# Altium schematic sheet SoftCore_SA_P4.SchDoc
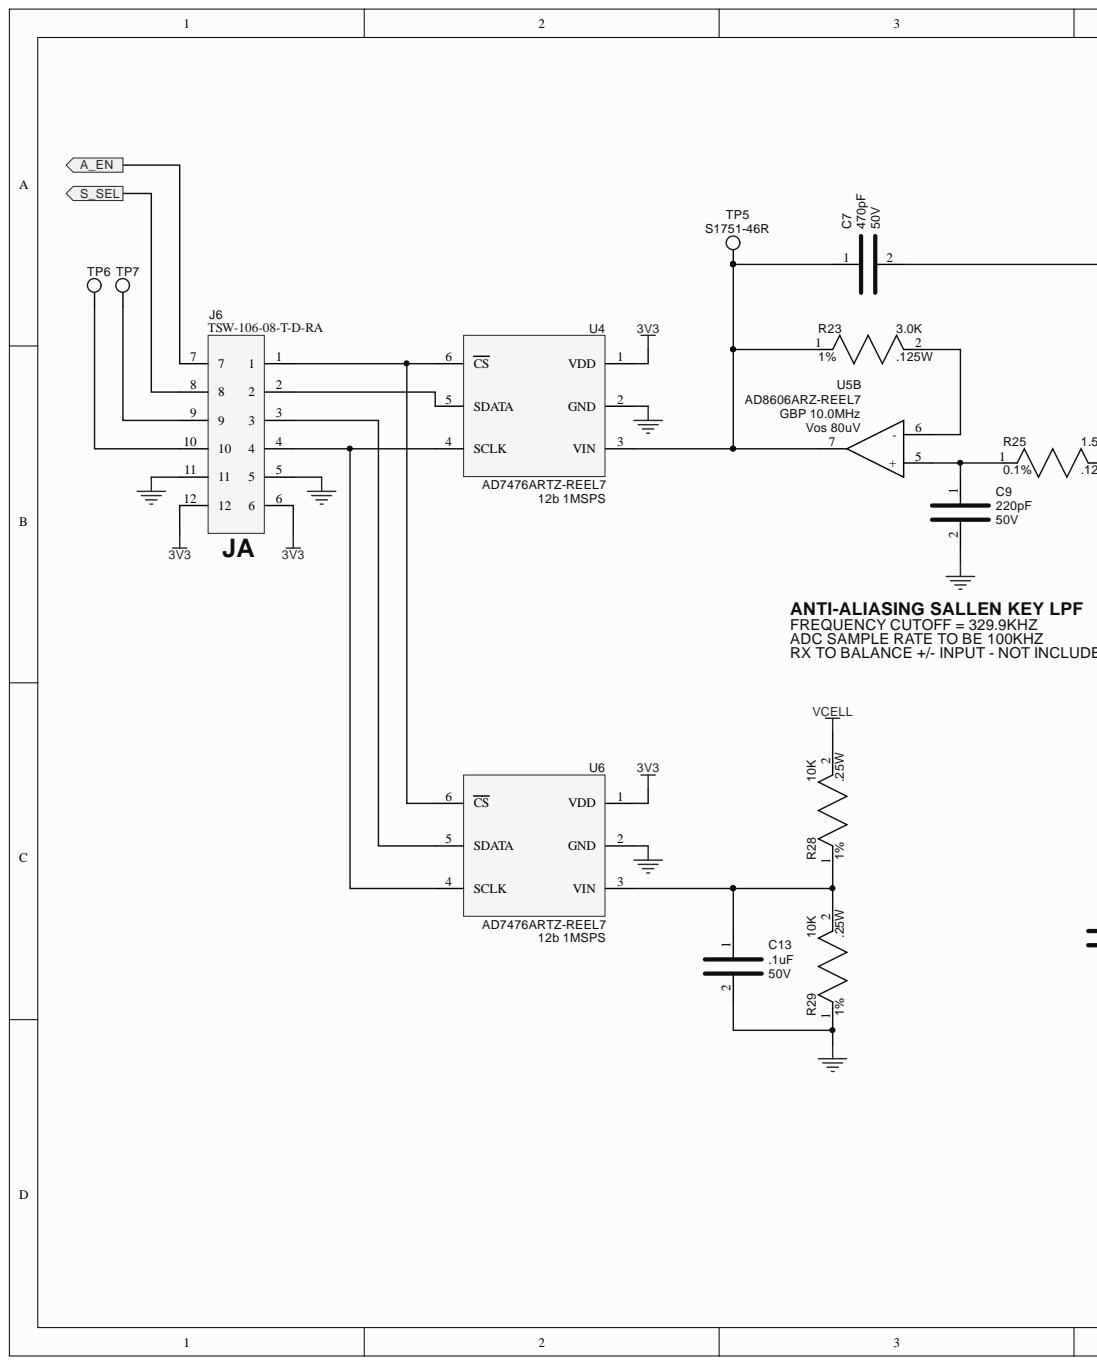
[diagram p0: SoftCore_SA_P4.SchDoc, left]
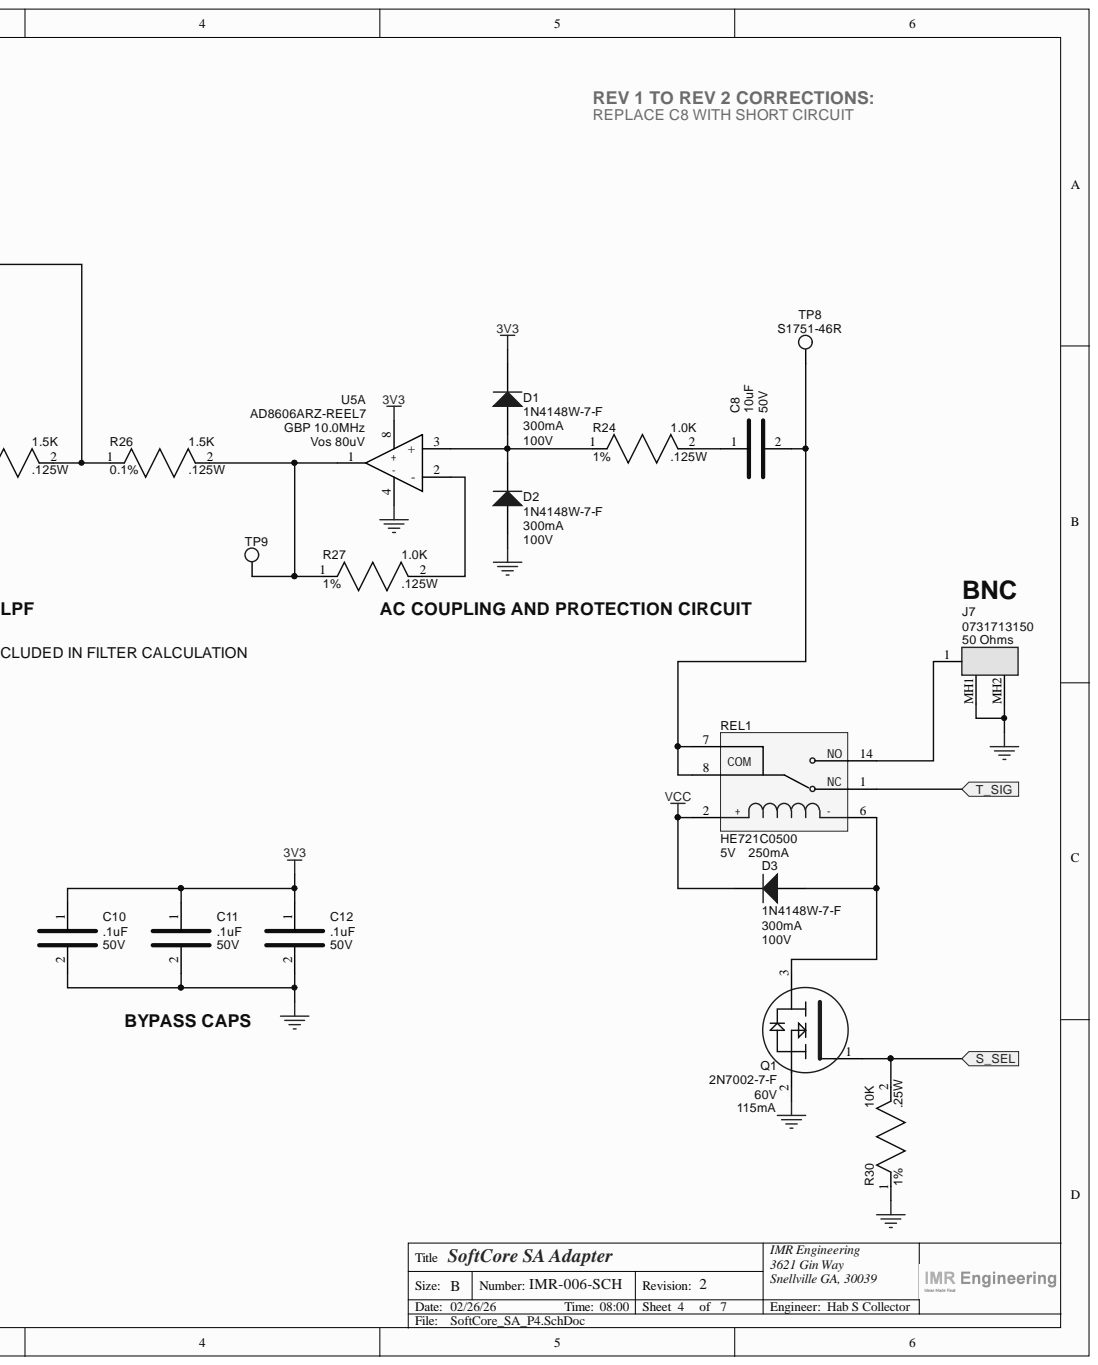
[diagram p1: SoftCore_SA_P4.SchDoc, right]

## Parts
C7  value 470pF  Voltage: 50V  [CAP_0805]  (hidden comment: CC0805JRNPO9BN471)
C8  value 10uF  Voltage: 50V  [CAP_0805]  (hidden comment: GRM21BR61H106KE43L)
C9  value 220pF  Voltage: 50V  [CAP_0805]  (hidden comment: CC0805JRNPO9BN221)
C10  value .1uF  Voltage: 50V  [CAP_0805]  (hidden comment: KGM21NR71H104KT)
C11  value .1uF  Voltage: 50V  [CAP_0805]  (hidden comment: KGM21NR71H104KT)
C12  value .1uF  Voltage: 50V  [CAP_0805]  (hidden comment: KGM21NR71H104KT)
C13  value .1uF  Voltage: 50V  [CAP_0805]  (hidden comment: KGM21NR71H104KT)
D1  1N4148W-7-F  value 100V  Current: 300mA  [SOD123]
D2  1N4148W-7-F  value 100V  Current: 300mA  [SOD123]
D3  1N4148W-7-F  value 100V  Current: 300mA  [SOD123]
J6  TSW-106-08-T-D-RA  [SAMTEC_TSW-106-08-T-D-RA]
J7  0731713150  value 50 Ohms  [731713150]
Q1  2N7002-7-F  value 60V  Current: 115mA  [SOT-23]
R23  value 3.0K  Power: .125W  Tolerance: 1%  [RES_0805]  (hidden comment: RC0805FR-133KL)
R24  value 1.0K  Power: .125W  Tolerance: 1%  [RES_0805]  (hidden comment: RC0805FR-071KL)
R25  value 1.5K  Power: .125W  Tolerance: 0.1%  [RES_0805]  (hidden comment: RT0805BRD071K5L)
R26  value 1.5K  Power: .125W  Tolerance: 0.1%  [RES_0805]  (hidden comment: RT0805BRD071K5L)
R27  value 1.0K  Power: .125W  Tolerance: 1%  [RES_0805]  (hidden comment: RC0805FR-071KL)
R28  value 10K  Power: .25W  Tolerance: 1%  [RES_0805]  (hidden comment: RC0805FR-7W10KL)
R29  value 10K  Power: .25W  Tolerance: 1%  [RES_0805]  (hidden comment: RC0805FR-7W10KL)
R30  value 10K  Power: .25W  Tolerance: 1%  [RES_0805]  (hidden comment: RC0805FR-7W10KL)
REL1  HE721C0500  value 5V  [HE700]
TP5  S1751-46R  [HARWIN_S1751]
TP6  MFG_PN  [TEST_POINT_20MIL_TH]  (value not drawn)  (no BOM)
TP7  MFG_PN  [TEST_POINT_20MIL_TH]  (value not drawn)  (no BOM)
TP8  S1751-46R  [HARWIN_S1751]
TP9  MFG_PN  [TEST_POINT_20MIL_TH]  (value not drawn)  (no BOM)
U4  AD7476ARTZ-REEL7  value 12b 1MSPS  [SOT-23-6]
U5  AD8606ARZ-REEL7  value GBP 10.0MHz  Vos: Vos 80uV  [SOIC-8_54]  (parts A,B)
U6  AD7476ARTZ-REEL7  value 12b 1MSPS  [SOT-23-6]

## Ports
A_EN, S_SEL, T_SIG

## Nets
3V3: C10.1 C11.1 C12.1 D1.2 J6.6 J6.12 U4.1(VDD) U5.8(+) U6.1(VDD)
GND: C9.2 C10.2 C11.2 C12.2 C13.2 D2.1 J6.5 J6.11 J7.MH1 J7.MH2 Q1.2 R29.1 R30.1 U4.2(GND) U5.4(-) U6.2(GND)
VCC: D3.2 REL1.2
VCELL: R28.2
A_EN: J6.7 ; port A_EN
S_SEL: J6.8 Q1.1 R30.2 ; port S_SEL
T_SIG: REL1.1 ; port T_SIG
NetC7_1: C7.1 R23.1 TP5.1 U4.3(VIN) U5.7
NetC7_2: C7.2 R25.2 R26.1
NetC8_1: C8.1 R24.2
NetC8_2: C8.2 REL1.7 REL1.8 TP8.1
NetC9_1: C9.1 R25.1 U5.5(+)
NetC13_1: C13.1 R28.1 R29.2 U6.3(VIN)
NetD1_1: D1.1 D2.2 R24.1 U5.3(+)
NetD3_1: D3.1 Q1.3 REL1.6
NetJ6_1: J6.1 U4.6(CS) U6.6(CS)
NetJ6_2: J6.2 U4.5(SDATA)
NetJ6_3: J6.3 U6.5(SDATA)
NetJ6_4: J6.4 U4.4(SCLK) U6.4(SCLK)
NetJ6_9: J6.9 TP7.1
NetJ6_10: J6.10 TP6.1
NetJ7_1: J7.1 REL1.14
NetR23_2: R23.2 U5.6(-)
NetR26_2: R26.2 R27.1 TP9.1 U5.1
NetR27_2: R27.2 U5.2(-)

## Library data of the parts
C7 = CAP_SMD_470PF_50V_0805: CAP CER 470PF 50V COG/NP0 0805; MFG: Yageo; Tolerance: 5%; Type: COG, NP0; Case/Package: 0805; Component Kind: Standard; MFG_PN: CC0805JRNPO9BN471; Supplier 1: DigiKey; Supplier Part Number 1: 311-1119-1-ND; Unit Price: .11; Library Name: IMR_LIB_SCH_R2.SchLib
C8 = CAP_SMD_10UF_50V_0805: CAP CER 10UF 50V X5R 0805; MFG: Murata Electronics; Case/Package: 0805; Component Kind: Standard; MFG_PN: GRM21BR61H106KE43L; Supplier 1: DigiKey; Supplier Part Number 1: 490-18663-1-ND; Unit Price: .28; Library Name: IMR_LIB_SCH_R2.SchLib
C9 = CAP_SMD_220PF_50V_0805: CAP CER 220PF 50V COG/NP0 0805; MFG: Yageo; Tolerance: 5%; Type: COG, NP0; Case/Package: 0805; Component Kind: Standard; MFG_PN: CC0805JRNPO9BN221; Supplier 1: DigiKey; Supplier Part Number 1: 311-1115-1-ND; Unit Price: .11; Library Name: IMR_LIB_SCH_R2.SchLib
C10-C13 = CAP_SMD_0.1UF_50V_0805: CAP CER 0.1UF 50V X7R 0805; MFG: KYOCERA AVX; Case/Package: 0805; Component Kind: Standard; MFG_PN: KGM21NR71H104KT; Supplier 1: DigiKey; Supplier Part Number 1: 478-KGM21NR71H104KTCT-ND; Unit Price: .1; Library Name: IMR_LIB_SCH_R2.SchLib
D1 D2 = DIODE_1N4148W-7-F: RELAY REED SPDT 250MA 5V; MFG: Diodes Inc; Case/Package: SOD-123; Component Kind: Standard; MFG_PN: 1N4148W-7-F; Supplier 1: DigiKey; Supplier Part Number 1: 1N4148W-FDICT-ND; Unit Price: .16; Library Name: IMR_LIB_SCH_R2.SchLib
D3 = DIODE_1N4148W-7-F: RELAY REED SPDT 250MA 5V; MFG: Diodes Inc; VALUE: 100V; Case/Package: SOD-123; Component Kind: Standard; MFG_PN: 1N4148W-7-F; Supplier 1: DigiKey; Supplier Part Number 1: 1N4148W-FDICT-ND; Unit Price: .16; Library Name: IMR_LIB_SCH_R2.SchLib
J6 = CONN_HEADER_12P_100MIL_RA: CONN HEADER R/A 12POS 2.54MM; MFG: Samtec Inc.; Case/Package: 2P_2.54MM; Component Kind: Standard; MFG_PN: TSW-106-08-T-D-RA; Supplier 1: Digi-Key; Supplier Part Number 1: SAM1049-06-ND; Unit Price: .93; Library Name: IMR_LIB_SCH_R2.SchLib
J7 = CONN_MOLEX_0731713150: CONN BNC RCPT R/A 50 OHM PCB; MFG: Molex; Case/Package: 73099-2; Component Kind: Standard; MFG_PN: 0731713150; Supplier 1: DigiKey; Supplier Part Number 1: WM20430-ND; Unit Price: 1.40; Library Name: IMR_LIB_SCH_R2.SchLib
Q1 = MOSFET_NCH_2N7002-7-F: MOSFET N-CH 60V 115MA SOT23-3; MFG: Diodes Inc; Case/Package: SOT-23; Component Kind: Standard; MFG_PN: 2N7002-7-F; Supplier 1: DigiKey; Supplier Part Number 1: 2N7002-FDICT-ND; Unit Price: .20; Library Name: IMR_LIB_SCH_R2.SchLib
R23 = RES_SMD_3.0K_0805_1/8W_1%: RES SMD 3.0K OHM 1% 1/8W 0805; MFG: Yageo; Case/Package: 0805; Component Kind: Standard; MFG_PN: RC0805FR-133KL; Supplier 1: DigiKey; Supplier Part Number 1: 13-RC0805FR-133KLCT-ND; Unit Price: .1; Library Name: IMR_LIB_SCH_R2.SchLib
R24 R27 = RES_SMD_1.0K_0805_1/8W_1%: RES SMD 1.0K OHM 1% 1/8W 0805; MFG: Yageo; Case/Package: 0805; Component Kind: Standard; MFG_PN: RC0805FR-071KL; Supplier 1: DigiKey; Supplier Part Number 1: 311-1.00KCRCT-ND; Unit Price: .1; Library Name: IMR_LIB_SCH_R2.SchLib
R25 R26 = RES_SMD_1.5K_0805_1/8W_0.1%: RES SMD 1.5K OHM 0.1% 1/8W 0805; MFG: Yageo; Case/Package: 0805; Component Kind: Standard; MFG_PN: RT0805BRD071K5L; Supplier 1: DigiKey; Supplier Part Number 1: YAG1814CT-ND; Unit Price: .16; Library Name: IMR_LIB_SCH_R2.SchLib
R28-R30 = RES_SMD_10K_0805_1/4W_1%: RES SMD 10K OHM 1% 1/4W 0805; MFG: Yageo; Case/Package: 0805; Component Kind: Standard; MFG_PN: RC0805FR-7W10KL; Supplier 1: DigiKey; Supplier Part Number 1: 13-RC0805FR-7W10KLCT-ND; Unit Price: .10; Library Name: IMR_LIB_SCH_R2.SchLib
REL1 = RELAY_HE721C0500: RELAY REED SPDT 250MA 5V; MFG: Littlefuse; Case/Package: HE700; Component Kind: Standard; Current: 250mA; MFG_PN: HE721C0500; Supplier 1: DigiKey; Supplier Part Number 1: HE112-ND; Unit Price: 3.77; Library Name: IMR_LIB_SCH_R2.SchLib
TP5 TP8 = CONN_TEST_POINT_S1751-46R: PCB TEST POINT CONNECT; MFG: Harwin Inc; Case/Package: S1751; Component Kind: Standard; MFG_PN: S1751-46R; Supplier 1: Digi-Key; Supplier Part Number 1: 952-1478-1-ND; Unit Price: .28; Library Name: IMR_LIB_SCH_R2.SchLib
TP6 TP7 TP9 = CONN_TEST_POINT_TH_PAD_20MIL: TEST POINT TH 20MIL HOLE; Library Name: IMR_LIB_SCH_R2.SchLib
U4 U6 = IC_AD7476ARTZ-REEL7: IC ADC 12BIT SAR SOT23-6; MFG: Analog Devices; Case/Package: SOT-23-6; Component Kind: Standard; MFG_PN: AD7476ARTZ-REEL7; Supplier 1: DigiKey; Supplier Part Number 1: AD7476ARTZ-REEL7CT-ND; Unit Price: 12.02; Library Name: IMR_LIB_SCH_R2.SchLib
U5 = IC_OPAMP_AD8606ARZ-REEL7: IC OPAMP GP 2 CIRCUIT 8SOIC; MFG: Analog Devices; Component Kind: Standard; MFG_PN: AD8606ARZ-REEL7; Package/Case: SOIC-8; Supplier 1: DigiKey; Supplier Part Number 1: AD8606ARZ-REEL7CT-ND; Unit Price: 4.94; Library Name: IMR_LIB_SCH_R2.SchLib
